# Altium schematic sheet sch2.SchDoc
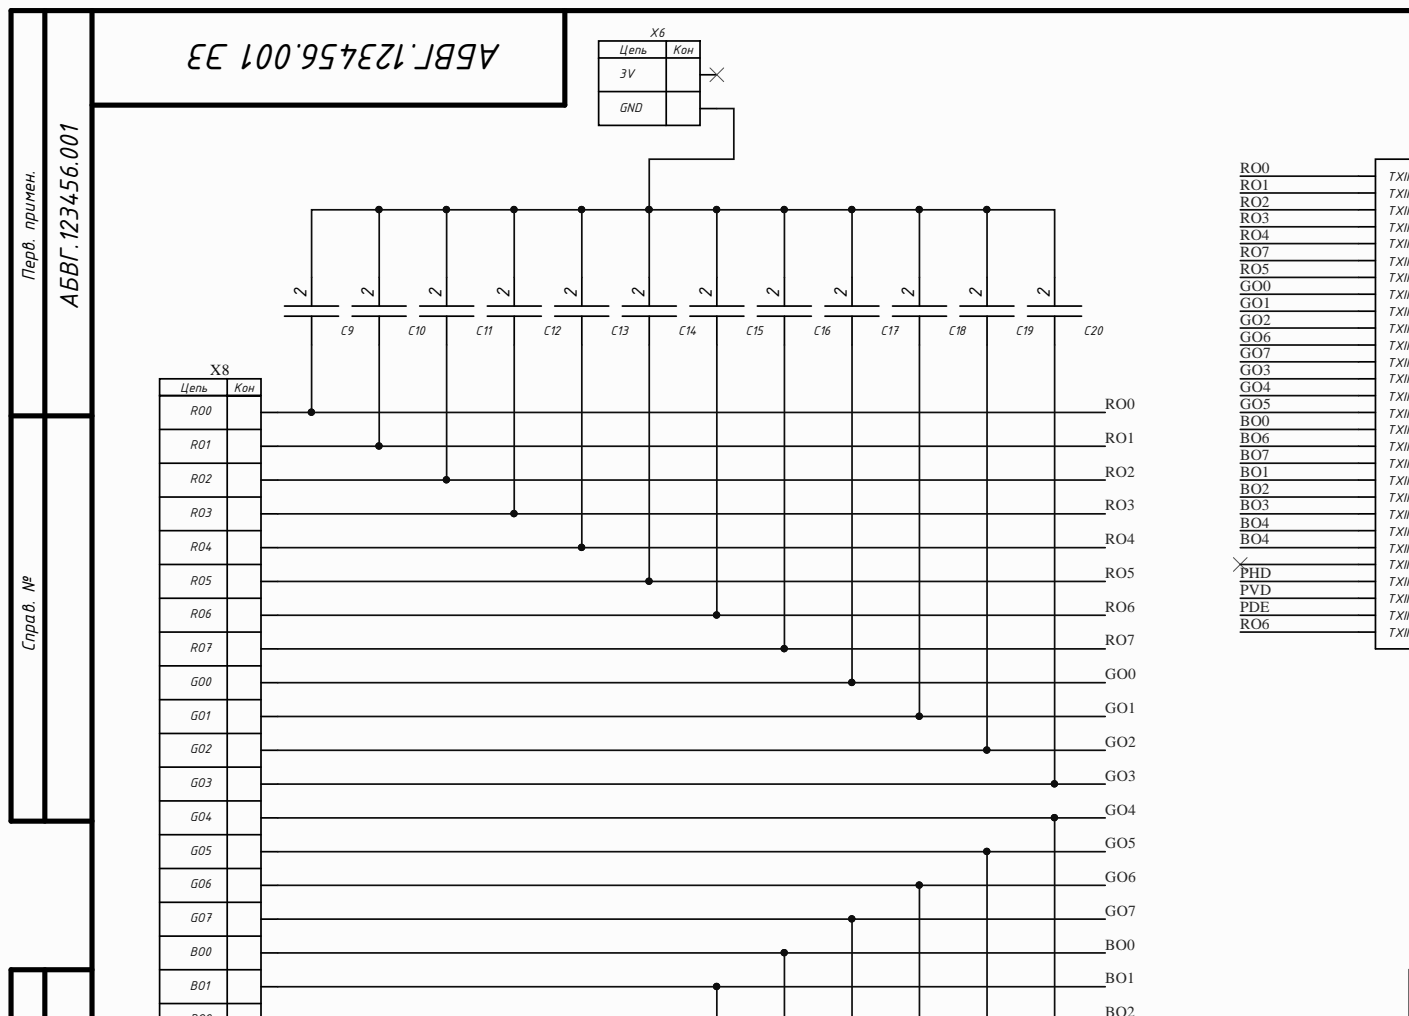
[diagram p0: sch2.SchDoc, top left]
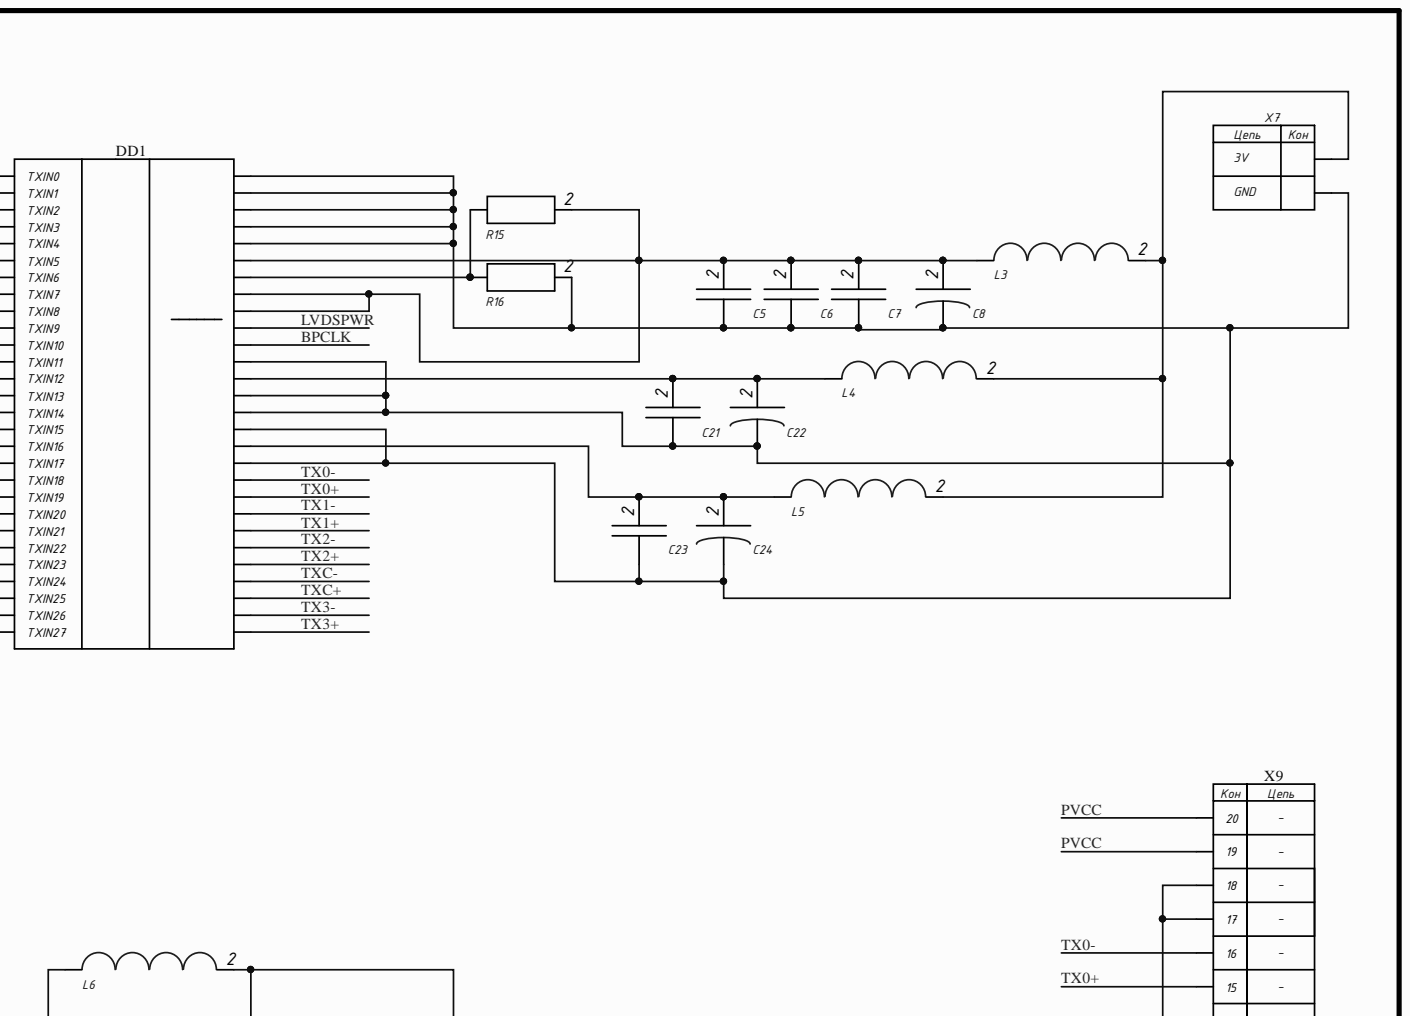
[diagram p1: sch2.SchDoc, top right]
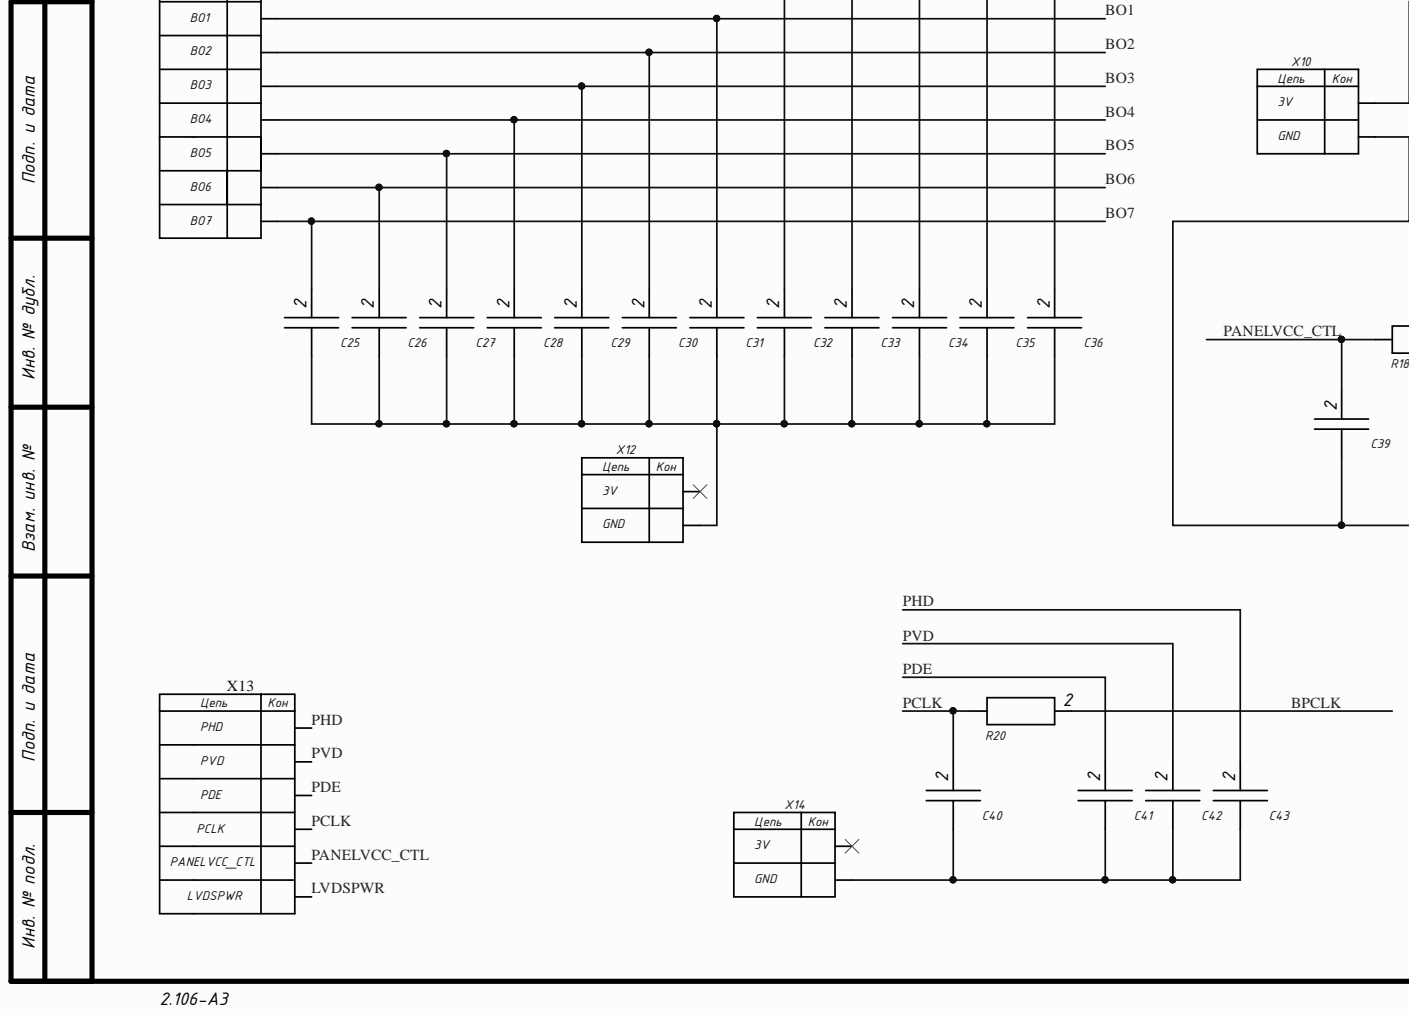
[diagram p2: sch2.SchDoc, bottom left]
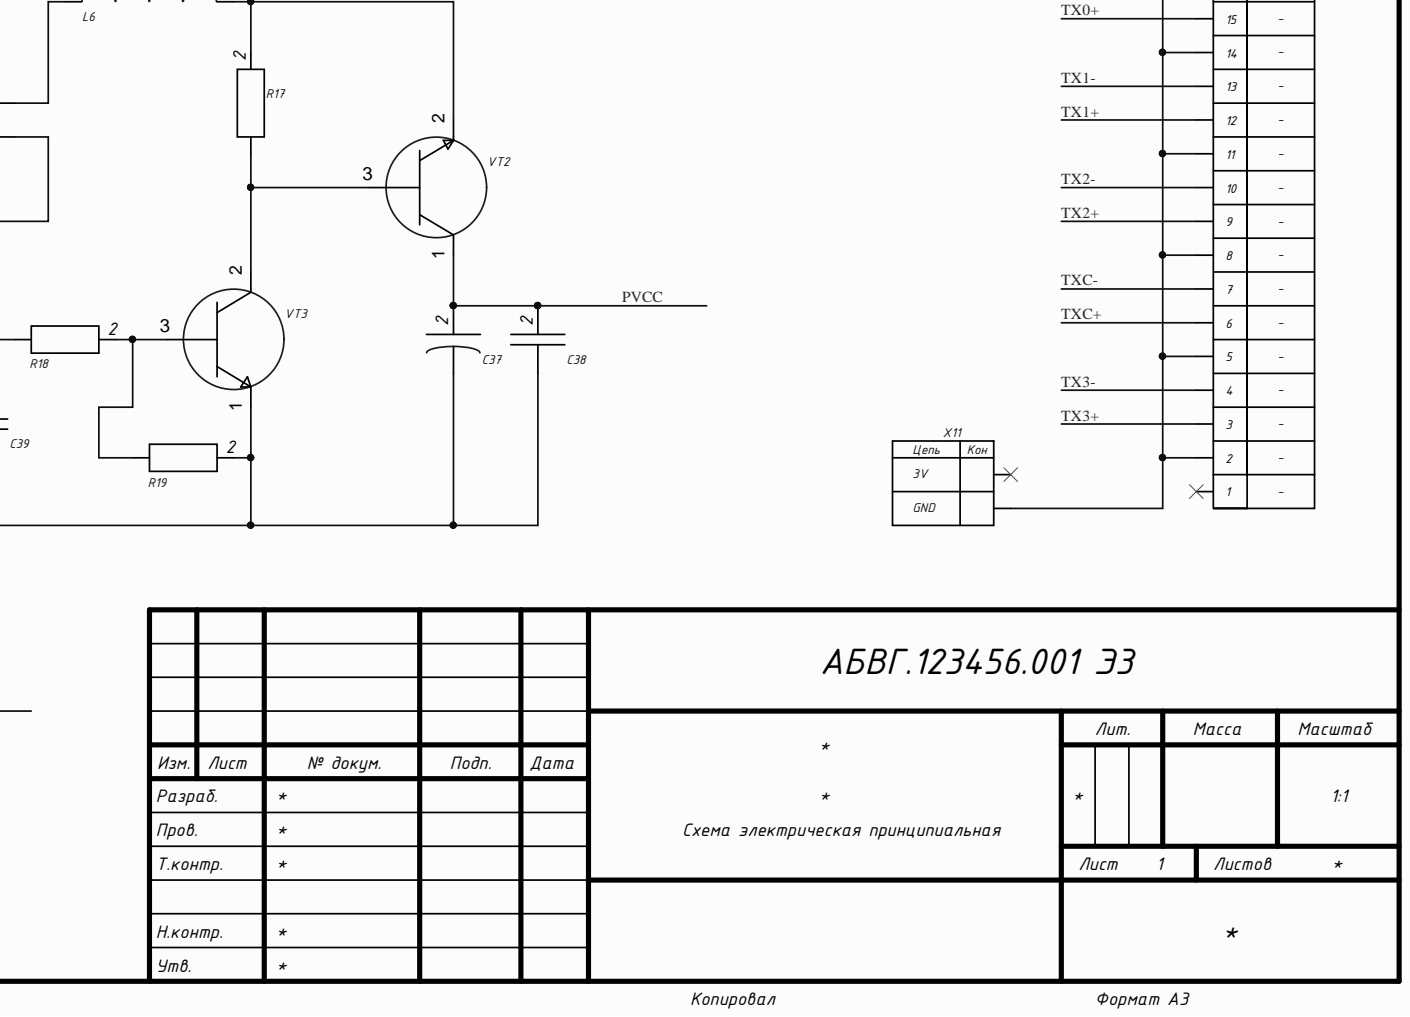
[diagram p3: sch2.SchDoc, bottom right]

## Parts
C5  (no value)  [Capacitor 0805]
C6  (no value)  [Capacitor 0805]
C7  (no value)  [Capacitor 0805]
C8  (no value)  [Capacitor 0805]
C9  (no value)  [Capacitor 0805]
C10  (no value)  [Capacitor 0805]
C11  (no value)  [Capacitor 0805]
C12  (no value)  [Capacitor 0805]
C13  (no value)  [Capacitor 0805]
C14  (no value)  [Capacitor 0805]
C15  (no value)  [Capacitor 0805]
C16  (no value)  [Capacitor 0805]
C17  (no value)  [Capacitor 0805]
C18  (no value)  [Capacitor 0805]
C19  (no value)  [Capacitor 0805]
C20  (no value)  [Capacitor 0805]
C21  (no value)  [Capacitor 0805]
C22  (no value)  [Capacitor 0805]
C23  (no value)  [Capacitor 0805]
C24  (no value)  [Capacitor 0805]
C25  (no value)  [Capacitor 0805]
C26  (no value)  [Capacitor 0805]
C27  (no value)  [Capacitor 0805]
C28  (no value)  [Capacitor 0805]
C29  (no value)  [Capacitor 0805]
C30  (no value)  [Capacitor 0805]
C31  (no value)  [Capacitor 0805]
C32  (no value)  [Capacitor 0805]
C33  (no value)  [Capacitor 0805]
C34  (no value)  [Capacitor 0805]
C35  (no value)  [Capacitor 0805]
C36  (no value)  [Capacitor 0805]
C37  (no value)  [Capacitor 0805]
C38  (no value)  [Capacitor 0805]
C39  (no value)  [Capacitor 0805]
C40  (no value)  [Capacitor 0805]
C41  (no value)  [Capacitor 0805]
C42  (no value)  [Capacitor 0805]
C43  (no value)  [Capacitor 0805]
DD1  (no value)  [THC63]
L3  (no value)  [Inductor 2520]
L4  (no value)  [Inductor 2520]
L5  (no value)  [Inductor 2520]
L6  (no value)  [Inductor 2520]
R15  (no value)  [Resistor 1206]
R16  (no value)  [Resistor 1206]
R17  (no value)  [Resistor 1206]
R18  (no value)  [Resistor 1206]
R19  (no value)  [Resistor 1206]
R20  (no value)  [Resistor 1206]
VT2  (no value)  [Transistor 1]
VT3  (no value)  [Transistor 1]
X6  (no value)  [VCC-GND]
X7  (no value)  [VCC-GND]
X8  (no value)  [BUSES]
X9  (no value)  [DF14A]
X10  (no value)  [VCC-GND]
X11  (no value)  [VCC-GND]
X12  (no value)  [VCC-GND]
X13  (no value)  [Inputs]
X14  (no value)  [VCC-GND]

## Nets
BO0: C32.2 DD1.16(TXIN15) X8.17
BO1: C31.2 DD1.19(TXIN18) X8.18
BO2: C30.2 DD1.20(TXIN19) X8.19
BO3: C29.2 DD1.21(TXIN20) X8.20
BO4: C28.2 DD1.22(TXIN21) DD1.23(TXIN22) X8.21
BO5: C27.2 X8.22
BO6: C26.2 DD1.17(TXIN16) X8.23
BO7: C25.2 DD1.18(TXIN17) X8.24
BPCLK: DD1.46 R20.2
GO0: C17.1 DD1.8(TXIN7) X8.9
GO1: C18.1 DD1.9(TXIN8) X8.10
GO2: C19.1 DD1.10(TXIN9) X8.11
GO3: C20.1 DD1.13(TXIN12) X8.12
GO4: C36.2 DD1.14(TXIN13) X8.13
GO5: C35.2 DD1.15(TXIN14) X8.14
GO6: C34.2 DD1.11(TXIN10) X8.15
GO7: C33.2 DD1.12(TXIN11) X8.16
LVDSPWR: DD1.47 X13.6
PANELVCC_CTL: C39.2 R18.1 X13.5
PCLK: C40.2 R20.1 X13.4
PDE: C41.2 DD1.27(TXIN26) X13.3
PHD: C43.2 DD1.25(TXIN24) X13.1
PVCC: C37.2 C38.2 VT2.1 X9.19 X9.20
PVD: C42.2 DD1.26(TXIN25) X13.2
RO0: C9.1 DD1.1(TXIN0) X8.1
RO1: C10.1 DD1.2(TXIN1) X8.2
RO2: C11.1 DD1.3(TXIN2) X8.3
RO3: C12.1 DD1.4(TXIN3) X8.4
RO4: C13.1 DD1.5(TXIN4) X8.5
RO5: C14.1 DD1.7(TXIN6) X8.6
RO6: C15.1 DD1.28(TXIN27) X8.7
RO7: C16.1 DD1.6(TXIN5) X8.8
TX0+: DD1.37 X9.15
TX0-: DD1.38 X9.16
TX1+: DD1.35 X9.12
TX1-: DD1.36 X9.13
TX2+: DD1.33 X9.9
TX2-: DD1.34 X9.10
TX3+: DD1.29 X9.3
TX3-: DD1.30 X9.4
TXC+: DD1.31 X9.6
TXC-: DD1.32 X9.7
NetC5_1: C5.1 C6.1 C7.1 C8.1 C21.1 C22.1 C23.1 C24.1 DD1.39 DD1.41 DD1.42 DD1.43 DD1.45 DD1.52 DD1.53 DD1.54 DD1.55 DD1.56 R16.2 X7.2
NetC5_2: C5.2 C6.2 C7.2 C8.2 DD1.48 DD1.49 DD1.51 L3.1 R15.2
NetC9_2: C9.2 C10.2 C11.2 C12.2 C13.2 C14.2 C15.2 C16.2 C17.2 C18.2 C19.2 C20.2 X6.2
NetC21_2: C21.2 C22.2 DD1.44 L4.1
NetC23_2: C23.2 C24.2 DD1.40 L5.1
NetC25_1: C25.1 C26.1 C27.1 C28.1 C29.1 C30.1 C31.1 C32.1 C33.1 C34.1 C35.1 C36.1 X12.2
NetC37_1: C37.1 C38.1 C39.1 R19.2 VT3.1 X10.2
NetC40_1: C40.1 C41.1 C42.1 C43.1 X14.2
NetDD1_50: DD1.50 R15.1 R16.1
NetL3_2: L3.2 L4.2 L5.2 X7.1
NetL6_1: L6.1 X10.1
NetL6_2: L6.2 R17.2 VT2.2
NetR17_1: R17.1 VT2.3 VT3.2
NetR18_2: R18.2 R19.1 VT3.3
NetX9_2: X9.2 X9.5 X9.8 X9.11 X9.14 X9.17 X9.18 X11.2

Not connected: DD1 24(TXIN23); X6 1; X9 1; X11 1; X12 1; X14 1

## Library data of the parts
C5-C7 C9-C21 C23 C25-C36 C38-C43 = Capacitor: Component Kind: Standard; Library Name: k7shared.IntLib
C8 C22 C24 C37 = Capacitor Duga: Component Kind: Standard; Library Name: k7shared.IntLib
DD1 = THC63: Component Kind: Standard; Library Name: k7sch2.IntLib
L3-L6 = Inductor: Component Kind: Standard; Library Name: k7shared.IntLib
R15-R20 = Resistor: Component Kind: Standard; Library Name: k7shared.IntLib
VT2 = Transistor 2_1: Component Kind: Standard; Library Name: k7shared.IntLib
VT3 = Transistor 1: Component Kind: Standard; Library Name: k7shared.IntLib
X6 X7 X10-X12 X14 = VCC-GND R: Component Kind: Standard; Library Name: k7shared.IntLib
X8 = BUSES: Component Kind: Standard; Library Name: k7sch2.IntLib
X9 = DF14A: Component Kind: Standard; Library Name: k7sch2.IntLib
X13 = Inputs: Component Kind: Standard; Library Name: k7sch2.IntLib
